# Altium schematic sheet ADS1256多通道采集模块.SchDoc
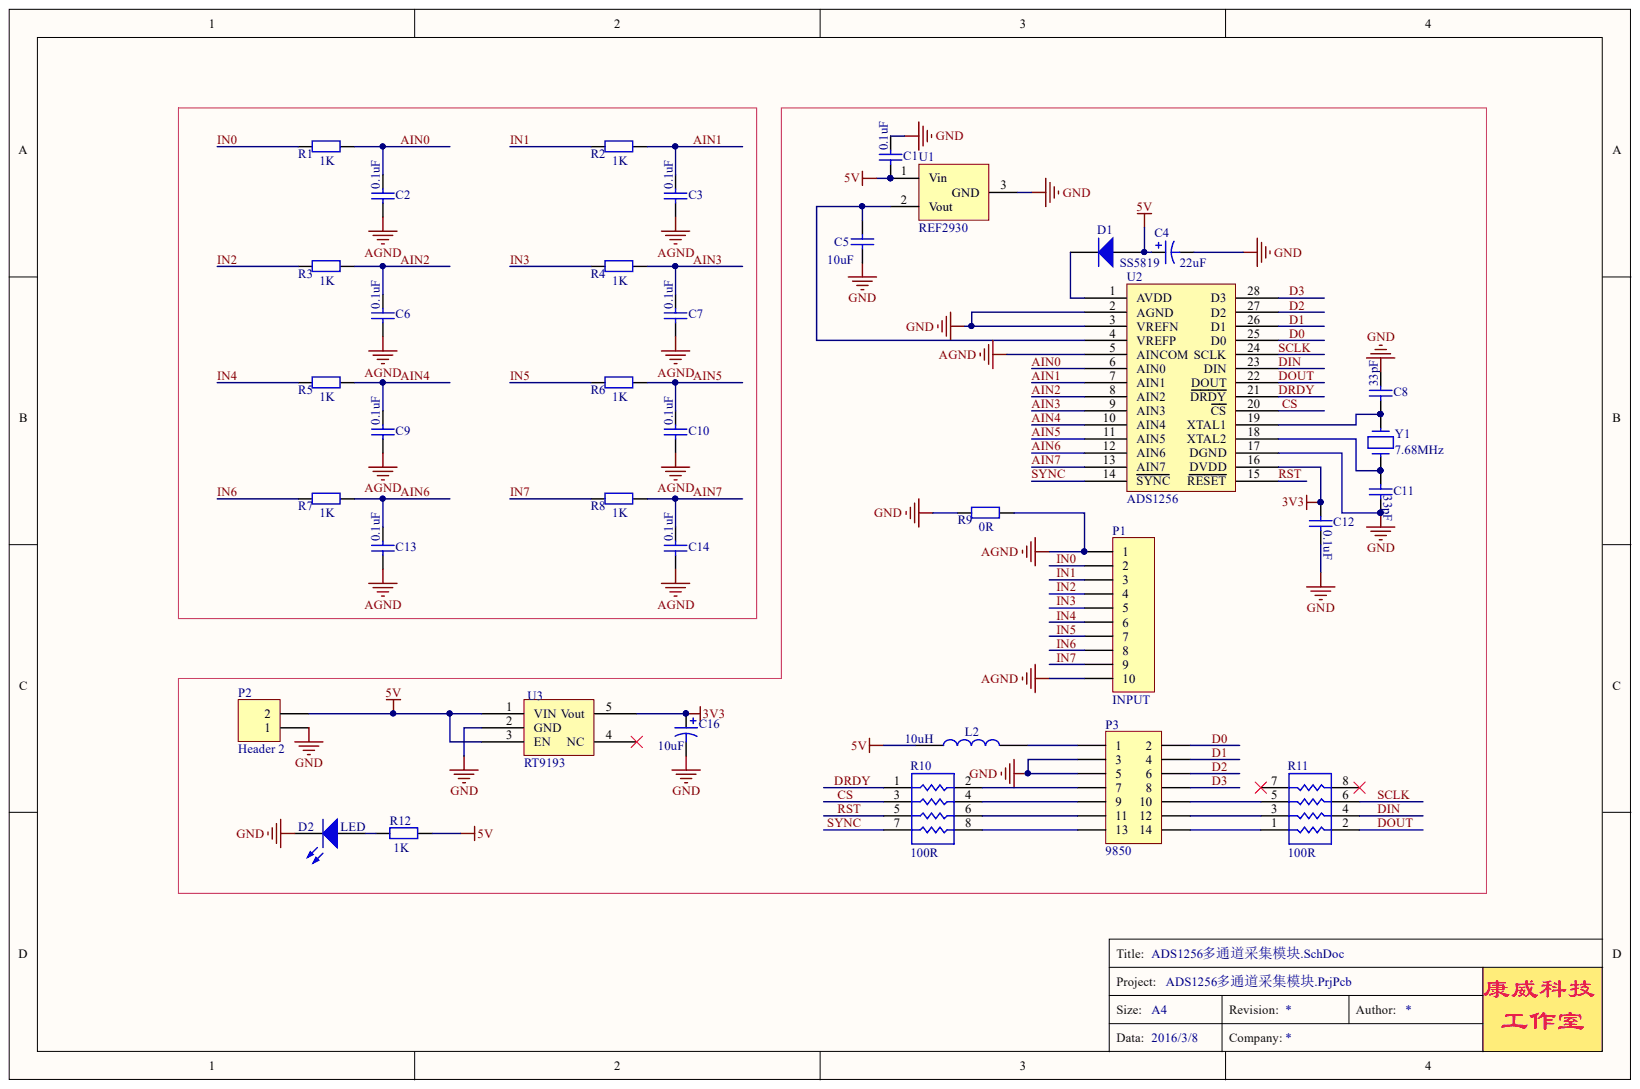
[diagram p0: ADS1256多通道采集模块.SchDoc]

## Parts
C1  value 0.1uF  [C0805]
C2  value 0.1uF  [C0805]
C3  value 0.1uF  [C0805]
C4  value 22uF  [C3528]
C5  value 10uF  [C0805]
C6  value 0.1uF  [C0805]
C7  value 0.1uF  [C0805]
C8  value 33pF  [C0805]
C9  value 0.1uF  [C0805]
C10  value 0.1uF  [C0805]
C11  value 33pF  [C0805]
C12  value 0.1uF  [C0805]
C13  value 0.1uF  [C0805]
C14  value 0.1uF  [C0805]
C16  value 10uF  [C3528]
D1  SS5819  [SOD123]
D2  LED  [LED0805R]
L2  10uH  [L0805]
P1  INPUT  [3.81  10p]
P2  Header 2  [3.81-2p]
P3  9850  [HDR2X7]
R1  value 1K  [R0805]
R2  value 1K  [R0805]
R3  value 1K  [R0805]
R4  value 1K  [R0805]
R5  value 1K  [R0805]
R6  value 1K  [R0805]
R7  value 1K  [R0805]
R8  value 1K  [R0805]
R9  value 0R  [R0805]
R10  100R  [RA4]
R11  100R  [RA4]
R12  value 1K  [R0805]
U1  REF2930  [REF2930]
U2  ADS1256  [TSSOP28]
U3  RT9193  [SOT23-5]
Y1  7.68MHz  [XTAL2]

## Nets
3V3: C12.2 C16.1 U2.16(DVDD) U3.5(Vout)
5V: C1.1 C4.1 D1.1 L2.1 P2.2 R12.2 U1.1 U3.1(VIN) U3.3(EN)
AGND: C2.1 C3.1 C6.1 C7.1 C9.1 C10.1 C13.1 C14.1 P1.1 P1.10 R9.2 U2.5(AINCOM)
GND: C1.2 C4.2 C5.1 C8.2 C11.1 C12.1 C16.2 D2.2 P2.1 P3.3 P3.5 R9.1 U1.3 U2.2(AGND) U2.3(VREFN) U2.17(DGND) U3.2(GND)
AIN0: C2.2 R1.2 U2.6(AIN0)
AIN1: C3.2 R2.2 U2.7(AIN1)
AIN2: C6.2 R3.2 U2.8(AIN2)
AIN3: C7.2 R4.2 U2.9(AIN3)
AIN4: C9.2 R5.2 U2.10(AIN4)
AIN5: C10.2 R6.2 U2.11(AIN5)
AIN6: C13.2 R7.2 U2.12(AIN6)
AIN7: C14.2 R8.2 U2.13(AIN7)
CS: R10.3 U2.20(CS)
D0: P3.2 U2.25(D0)
D1: P3.4 U2.26(D1)
D2: P3.6 U2.27(D2)
D3: P3.8 U2.28(D3)
DIN: R11.4 U2.23(DIN)
DOUT: R11.2 U2.22(DOUT)
DRDY: R10.1 U2.21(DRDY)
IN0: P1.2 R1.1
IN1: P1.3 R2.1
IN2: P1.4 R3.1
IN3: P1.5 R4.1
IN4: P1.6 R5.1
IN5: P1.7 R6.1
IN6: P1.8 R7.1
IN7: P1.9 R8.1
RST: R10.5 U2.15(RESET)
SCLK: R11.6 U2.24(SCLK)
SYNC: R10.7 U2.14(SYNC)
NetC5_2: C5.2 U1.2 U2.4(VREFP)
NetC8_1: C8.1 U2.19(XTAL1) Y1.2
NetC11_2: C11.2 U2.18(XTAL2) Y1.1
NetD1_2: D1.2 U2.1(AVDD)
NetD2_1: D2.1 R12.1
NetL2_2: L2.2 P3.1
NetP3_7: P3.7 R10.2
NetP3_9: P3.9 R10.4
NetP3_10: P3.10 R11.5
NetP3_11: P3.11 R10.6
NetP3_12: P3.12 R11.3
NetP3_13: P3.13 R10.8
NetP3_14: P3.14 R11.1

Not connected: R11 7 8; U3 4(NC)

## Library data of the parts
C1-C3 C5-C14 = Cap: Capacitor; Component Kind: Standard; LatestRevisionDate: 17-Jul-2002; LatestRevisionNote: Re-released for DXP Platform.; Library Name: Miscellaneous Devices.IntLib; PackageDescription: Radial Cap, Thru-Hole; 2 Leads; 0.3 in Pin Spacing; PackageReference: RAD-0.3; Published: 8-Jun-2000; Signal Integrity: Cap; Simulation: CAP; Publisher: Altium Limited
C4 C16 = Cap Pol1: Polarized Capacitor (Radial); Component Kind: Standard; LatestRevisionDate: 17-Jul-2002; LatestRevisionNote: Re-released for DXP Platform.; Library Name: Miscellaneous Devices.IntLib; PackageDescription: Polarized Capacitor (Radial); 2 Leads; PackageReference: RB7.6-15; Published: 8-Jun-2000; Signal Integrity: Cap Pol; Simulation: CAP; Publisher: Altium Limited
D1 = Diode 1N4007: 1 Amp General Purpose Rectifier; Code_JEDEC: DO-204-AL; Component Kind: Standard; ComponentLink1Description: Manufacturer Link; ComponentLink1URL: http://www.fairchildsemi.com/; ComponentLink2Description: Datasheet; ComponentLink2URL: http://www.fairchildsemi.com/ds/1N%2F1N4001.pdf; DatasheetVersion: 1998; LatestRevisionDate: 17-Jul-2002; LatestRevisionNote: Re-released for DXP Platform.; Library Name: Miscellaneous Devices.IntLib; PackageDescription: Diode, Axial; Body 5.2 x 2.7 mm (LxDia. max), Lead Dia. 0.86 mm (max); PackageReference: DO-41; PackageVersion: Aug-1999; Published: 8-Jun-2000; Signal Integrity: 1N4007; Simulation: 1N4007; Publisher: Altium Limited
L2 = INDUCTOR1: Component Kind: Standard
P1 = Header 10: Header, 10-Pin; Component Kind: Standard; LatestRevisionDate: 17-Jul-2002; LatestRevisionNote: Re-released for DXP Platform.; Signal Integrity: Connector; Library Name: Xiaowei.SchLib; Publisher: Altium Limited
P2 = Header 2: Header, 2-Pin; Component Kind: Standard; LatestRevisionDate: 17-Jul-2002; LatestRevisionNote: Re-released for DXP Platform.; Signal Integrity: Connector; Library Name: Xiaowei.SchLib; Publisher: Altium Limited
P3 = Header 7X2: Header, 7-Pin, Dual row; Component Kind: Standard; LatestRevisionDate: 17-Jul-2002; LatestRevisionNote: Re-released for DXP Platform.; Signal Integrity: Connector; Library Name: Xiaowei.SchLib; Publisher: Altium Limited
R1-R9 R12 = Res2: Resistor; Component Kind: Standard; LatestRevisionDate: 17-Jul-2002; LatestRevisionNote: Re-released for DXP Platform.; Library Name: Miscellaneous Devices.IntLib; PackageDescription: Axial Device, Thru-Hole; 2 Leads; 0.4 in Pin Spacing; PackageReference: AXIAL-0.4; Published: 8-Jun-2000; Signal Integrity: Res; Simulation: RESISTOR; Publisher: Altium Limited
R10 R11 = Res Pack5: Component Kind: Standard; Library Name: Miscellaneous Devices.SchLib
U1 = REF29XX: Component Kind: Standard; Library Name: Xiaowei.SchLib
U3 = TLV700xx: Component Kind: Standard; Library Name: Xiaowei.SchLib
Y1 = CRYSTAL: Component Kind: Standard; Description: Crystal
D2 = LED: Component Kind: Standard; LatestRevisionDate: 08-Jul-2005; LatestRevisionNote: Mech Layer 1 removed.; PackageDescription: LED; 2 Leads; PackageReference: LED-0; Published: 8-Jun-2000; Library Name: Xiaowei.SchLib; Publisher: Altium Limited
U2 = ADS1256: Component Kind: Standard; Library Name: Xiaowei.SchLib
